# Altium schematic sheet ESP32_VCC.SchDoc
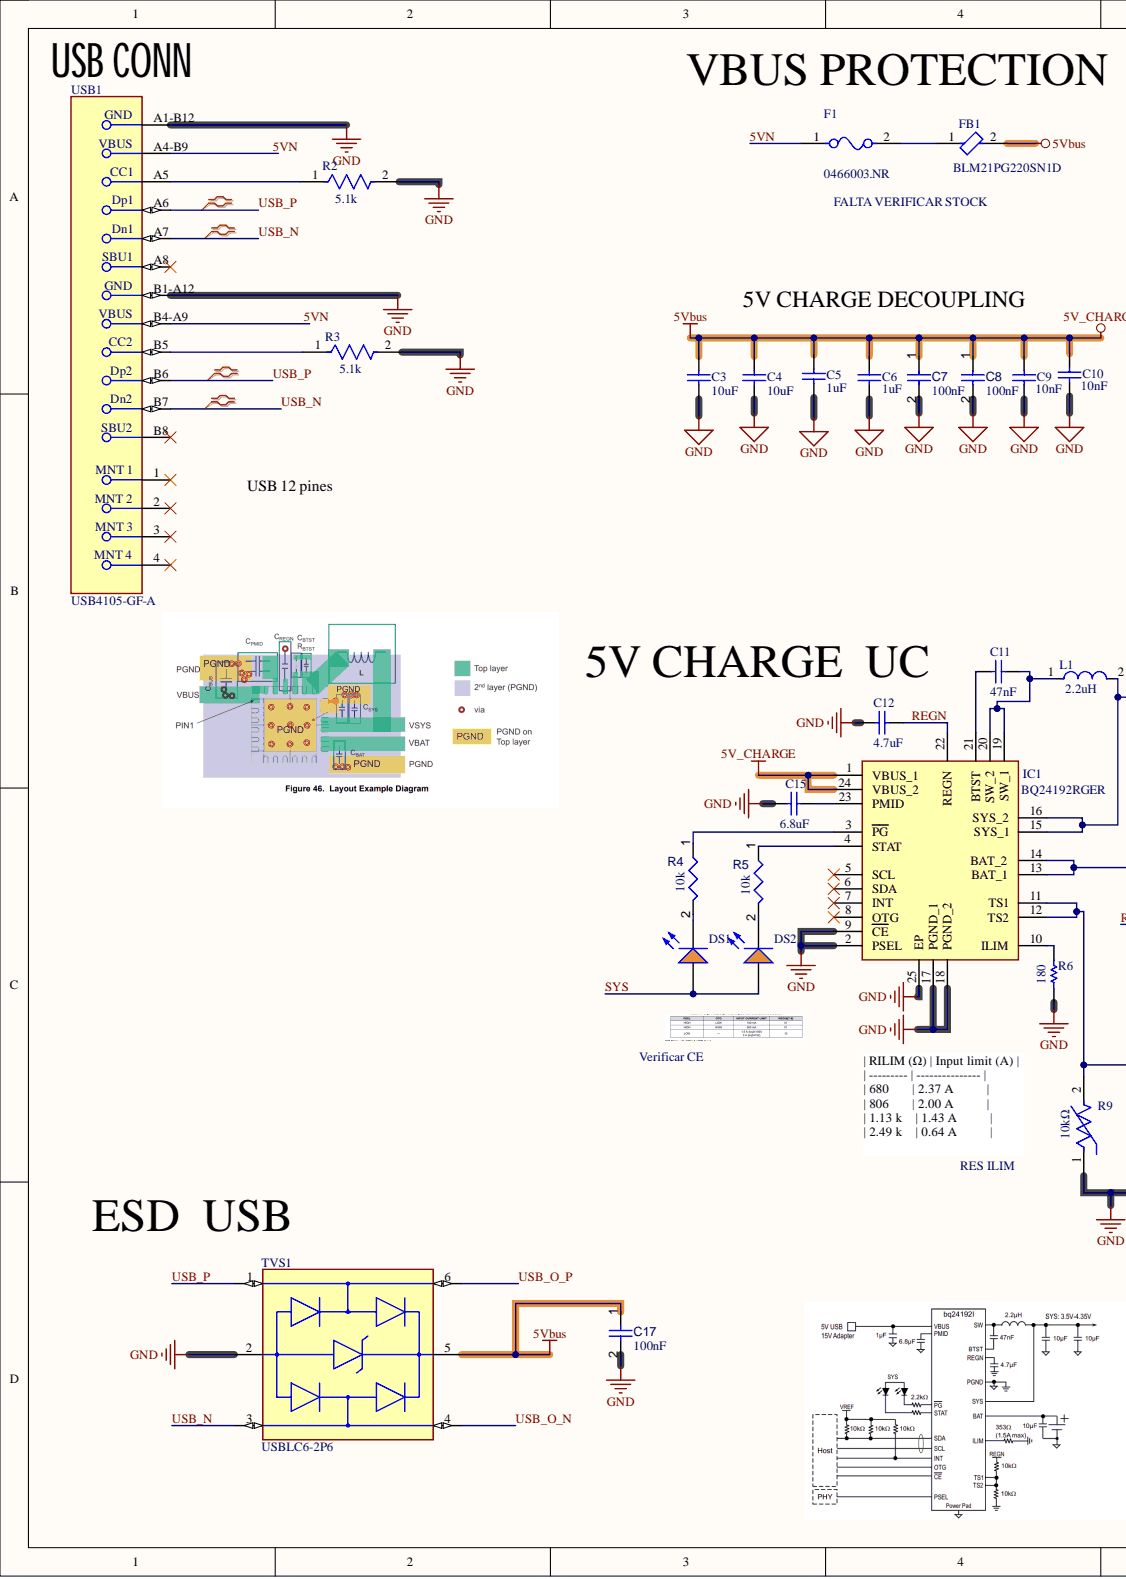
[diagram p0: ESP32_VCC.SchDoc, left]
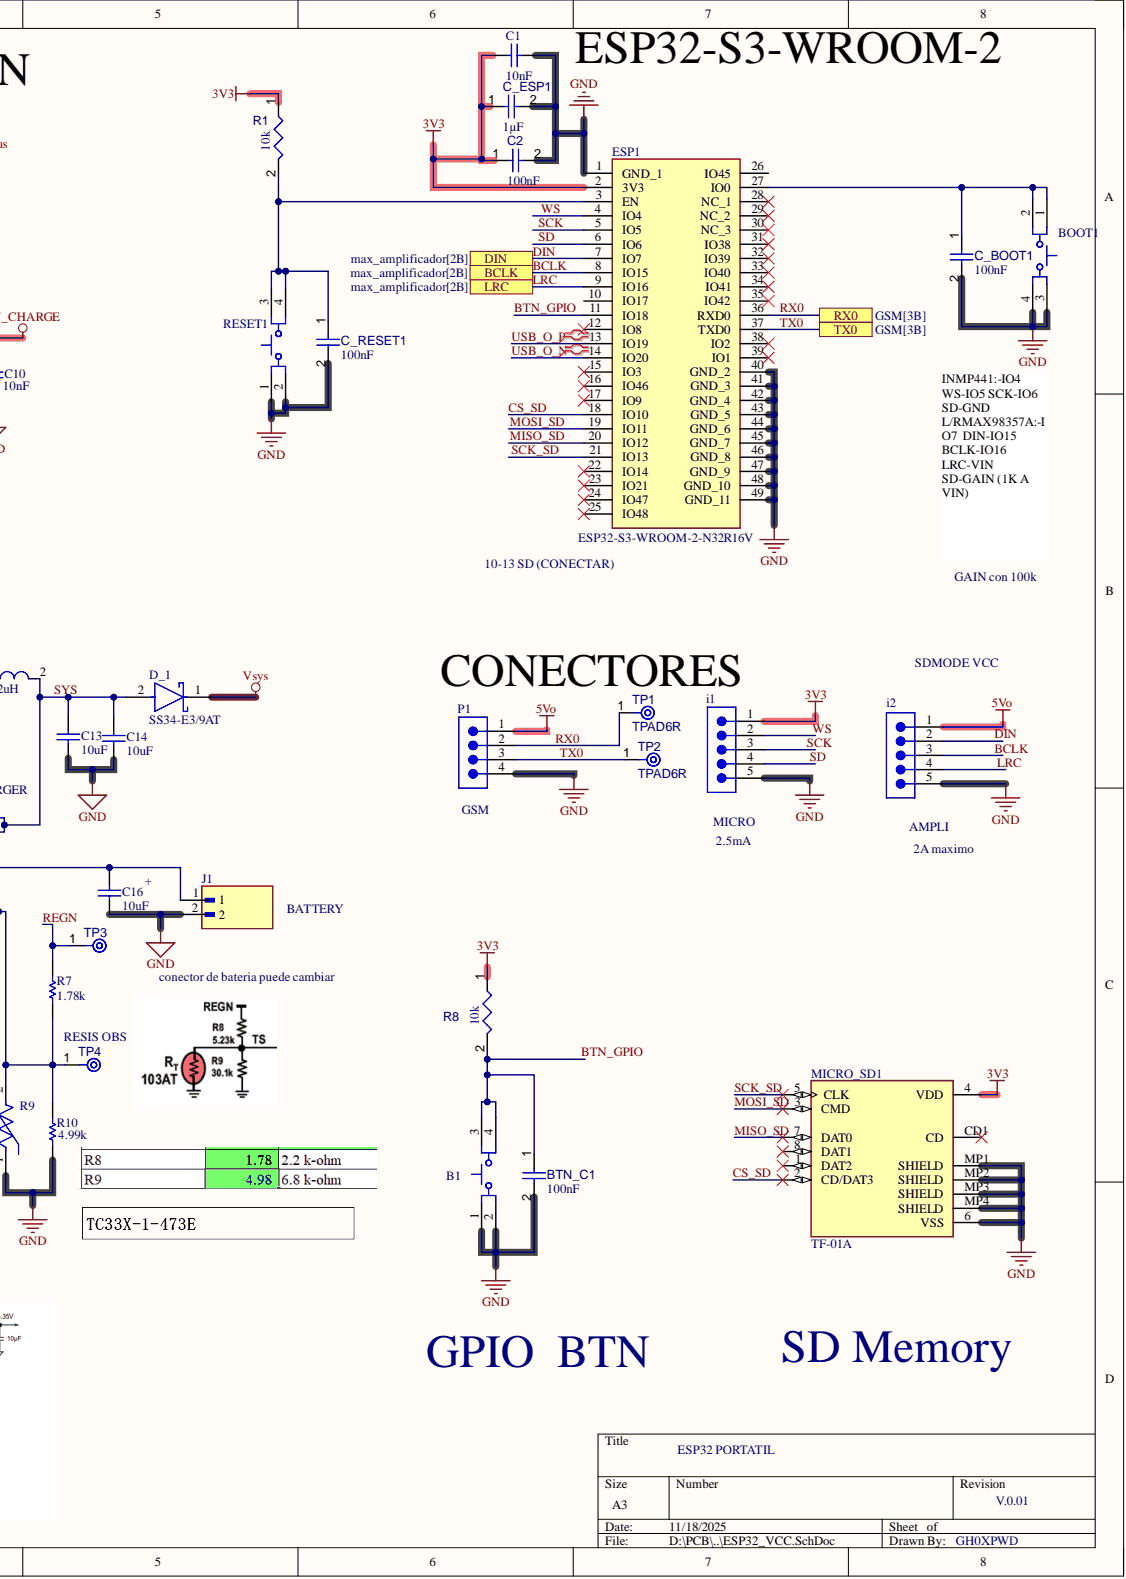
[diagram p1: ESP32_VCC.SchDoc, right]

## Parts
B1  TL3342F160QG  [ESWI-TL3342F160QG_V]  (value not drawn)
BOOT1  TL3342F160QG  [ESWI-TL3342F160QG_V]  (value not drawn)
BTN_C1  value 100nF  [CAPC1005X56X25ML10T15]  (hidden comment: Capacitor 0.1 uF +/- 5% 16 V 0402)
C1  value 10nF  [CAPC0603X33X15NL03T05]  (hidden comment: 0201ZC103JAT2A)
C2  value 100nF  [CAPC1005X56X25NL10T15]  (hidden comment: Capacitor 0.1 uF +/- 5% 16 V 0402)
C3  value 10uF  [CAPC0603(1608)100_N]  (hidden comment: CAP 10uF 6.3V 0603(1608))
C4  value 10uF  [CAPC0603(1608)100_N]  (hidden comment: CAP 10uF 6.3V 0603(1608))
C5  value 1uF  [CAPC1608X90X35NL15T15]  (hidden comment: 0603YD105KAT4A)
C6  value 1uF  [CAPC1608X90X35NL15T15]  (hidden comment: 0603YD105KAT4A)
C7  value 100nF  [CAPC1005X56X25ML10T15]  (hidden comment: Capacitor 0.1 uF +/- 5% 16 V 0402)
C8  value 100nF  [CAPC1005X56X25ML10T15]  (hidden comment: Capacitor 0.1 uF +/- 5% 16 V 0402)
C9  value 10nF  [CAPC0603X33X15NL03T05]  (hidden comment: 0201ZC103JAT2A)
C10  value 10nF  [CAPC0603X33X15NL03T05]  (hidden comment: 0201ZC103JAT2A)
C11  value 47nF  [CAPC1608X92X38NL15T08]  (hidden comment: VJ0603Y473KXAAC)
C12  value 4.7uF  [CAPC0603(1608)100_N]  (hidden comment: CAP 4.7uF 10V 0603(1608))
C13  value 10uF  [CAPC0603(1608)100_L]  (hidden comment: CAP 10uF 6.3V 0603(1608))
C14  value 10uF  [CAPC0603(1608)100_L]  (hidden comment: CAP 10uF 6.3V 0603(1608))
C15  value 6.8uF  [CAPC1609X100X15NL20]  (hidden comment: TACL685K010XTA)
C16  value 10uF  [CAPC0603(1608)100_N]  (hidden comment: CAP 10uF 6.3V 0603(1608))
C17  value 100nF  [CAPC1005X56X25ML10T15]  (hidden comment: Capacitor 0.1 uF +/- 5% 16 V 0402)
C_BOOT1  value 100nF  [CAPC1005X56X25ML10T15]  (hidden comment: Capacitor 0.1 uF +/- 5% 16 V 0402)
C_ESP1  value 1µF  [CAPC1608X94X35NL15T15]  (hidden comment: Capacitor 1 uF +/- 10% 16 V 0603)
C_RESET1  value 100nF  [CAPC1005X56X25ML10T15]  (hidden comment: Capacitor 0.1 uF +/- 5% 16 V 0402)
D_1  SS34-E3/9AT  [FP-SMC-MFG]
DS1  LTST-C190KFKT  [LTST-C190KFKT_V]  (value not drawn)
DS2  LTST-C190KFKT  [LTST-C190KFKT_V]  (value not drawn)
ESP1  ESP32-S3-WROOM-2-N32R16V  [ESP32S3WROOM2N32R16V]
F1  0466003.NR  [FP-0466-MFG]
FB1  BLM21PG220SN1D  [FP-BLM21-0_2-t0_85-e0_5-IPC_A]
i1  PHT-105-02-L-S  [SMTC-PHT-105-02-X-S]  (value not drawn)
i2  PHT-105-02-L-S  [SMTC-PHT-105-02-X-S]  (value not drawn)
IC1  BQ24192RGER  [QFN50P400X400X100-25N-D]
J1  S2B-XH-A(LF)(SN)  [JST_S2B-XH-A(LF)(SN)]  (value not drawn)
L1  Inductance: 2.2uH  [FP-MPX1D1250-MFG]  (hidden comment: MPX1D1250L2R2)
MICRO_SD1  TF-01A  [TF-01A]
P1  PHT-104-01-L-S  [SMTC-PHT-104-01-X-S]  (value not drawn)
R1  value 10k  [RESC1608X55X25LL10T15]  (hidden comment: Resistor 10k +/-1% 0603 100 mW)
R2  Resistance: 5.1k  [FP-RMCF0603-IPC_A]  (hidden comment: RMCF0603JG5K10)
R3  Resistance: 5.1k  [FP-RMCF0603-IPC_A]  (hidden comment: RMCF0603JG5K10)
R4  value 10k  [RESC1608X55X25LL10T15]  (hidden comment: Resistor 10k +/-1% 0603 100 mW)
R5  value 10k  [RESC1608X55X25LL10T15]  (hidden comment: Resistor 10k +/-1% 0603 100 mW)
R6  value 180  [RESC1608X55X25ML10T15]  (hidden comment: RC0603FR-07180RL)
R7  value 1.78k  [RESC1005X40X25LL05T05]  (hidden comment: CRCW0402178KFKED)
R8  value 10k  [RESC1608X55X25LL10T15]  (hidden comment: Resistor 10k +/-1% 0603 100 mW)
R9  Resistance: 10kΩ  [FP-NTCLE100E3103JT2-MFG]  (hidden comment: NTC)
R10  value 4.99k  [RESC1005X40X25LL05T10]  (hidden comment: RC0402FR-07499KL)
RESET1  TL3342F160QG  [ESWI-TL3342F160QG_V]  (value not drawn)
TP1  TPAD6R  [TP06R]  (no BOM)
TP2  TPAD6R  [TP06R]  (no BOM)
TP3  TPAD6R  [TP06R]  (value not drawn)  (no BOM)
TP4  TPAD6R  [TP06R]  (value not drawn)  (no BOM)
TVS1  USBLC6-2P6  [FP-SOT-666-MFG]
USB1  USB4105-GF-A  [FP-USB4105-GF-A-MFG]

## Ports
BCLK, DIN, LRC, RX0, TX0

## Nets
3V3: C1.2 C2.1 C_ESP1.1 ESP1.2(3V3) i1.1 MICRO_SD1.4(VDD) R1.1 R8.1
5V_CHARGE: C3.2 C4.2 C5.1 C6.1 C7.1 C8.1 C9.2 C10.2 C17.1 FB1.2 IC1.1(VBUS_1) IC1.24(VBUS_2) TVS1.5
5Vo: i2.1 P1.1
GND: B1.1 B1.2 BOOT1.3 BOOT1.4 BTN_C1.2 C1.1 C2.2 C3.1 C4.1 C5.2 C6.2 C7.2 C8.2 C9.1 C10.1 C12.2 C13.1 C14.1 C15.1 C16.1 C17.2 C_BOOT1.2 C_ESP1.2 C_RESET1.2 ESP1.1(GND_1) ESP1.40(GND_2) ESP1.41(GND_3) ESP1.42(GND_4) ESP1.43(GND_5) ESP1.44(GND_6) ESP1.45(GND_7) ESP1.46(GND_8) ESP1.47(GND_9) ESP1.48(GND_10) ESP1.49(GND_11) i1.5 i2.5 IC1.2(PSEL) IC1.9(CE) IC1.17(PGND_1) IC1.18(PGND_2) IC1.25(EP) J1.2 MICRO_SD1.6(VSS) MICRO_SD1.MP1(SHIELD) MICRO_SD1.MP2(SHIELD) MICRO_SD1.MP3(SHIELD) MICRO_SD1.MP4(SHIELD) P1.4 R2.2 R3.2 R6.2 R9.1 R10.1 RESET1.1 RESET1.2 TVS1.2 USB1.A1-B12 USB1.B1-A12
Vsys: D_1.1
5VN: F1.1 USB1.A4-B9 USB1.B4-A9
BCLK: ESP1.8(IO15) i2.3 ; port BCLK
BTN_GPIO: B1.3 B1.4 BTN_C1.1 ESP1.11(IO18) R8.2
CS_SD: ESP1.18(IO10) MICRO_SD1.2(CD/DAT3)
DIN: ESP1.7(IO7) i2.2 ; port DIN
LRC: ESP1.9(IO16) i2.4 ; port LRC
MISO_SD: ESP1.20(IO12) MICRO_SD1.7(DAT0)
MOSI_SD: ESP1.19(IO11) MICRO_SD1.3(CMD)
REGN: C12.1 IC1.22(REGN) R7.2 TP3.1
RX0: ESP1.36(RXD0) P1.2 TP1.1 ; port RX0
SCK: ESP1.5(IO5) i1.3
SCK_SD: ESP1.21(IO13) MICRO_SD1.5(CLK)
SD: ESP1.6(IO6) i1.4
SYS: C13.2 C14.2 D_1.2 DS1.1 DS2.1 IC1.15(SYS_1) IC1.16(SYS_2) L1.2
TX0: ESP1.37(TXD0) P1.3 TP2.1 ; port TX0
USB_N: TVS1.3 USB1.A7 USB1.B7
USB_O_N: ESP1.14(IO20) TVS1.4
USB_O_P: ESP1.13(IO19) TVS1.6
USB_P: TVS1.1 USB1.A6 USB1.B6
WS: ESP1.4(IO4) i1.2
NetBOOT1_1: BOOT1.1 BOOT1.2 C_BOOT1.1 ESP1.27(IO0)
NetC11_1: C11.1 IC1.21(BTST)
NetC11_2: C11.2 IC1.19(SW_1) IC1.20(SW_2) L1.1
NetC15_2: C15.2 IC1.23(PMID)
NetC16_2: C16.2 IC1.13(BAT_1) IC1.14(BAT_2) J1.1
NetC_RESET1_1: C_RESET1.1 ESP1.3(EN) R1.2 RESET1.3 RESET1.4
NetDS1_2: DS1.2 R4.2
NetDS2_2: DS2.2 R5.2
NetF1_2: F1.2 FB1.1
NetIC1_3: IC1.3(PG) R4.1
NetIC1_4: IC1.4(STAT) R5.1
NetIC1_10: IC1.10(ILIM) R6.1
NetIC1_11: IC1.11(TS1) IC1.12(TS2) R7.1 R9.2 R10.2 TP4.1
NetR2_1: R2.1 USB1.A5
NetR3_1: R3.1 USB1.B5

Not connected: ESP1 10(IO17) 12(IO8) 15(IO3) 16(IO46) 17(IO9) 22(IO14) 23(IO21) 24(IO47) 25(IO48) 26(IO45) 28(NC_1) 29(NC_2) 30(NC_3) 31(IO38) 32(IO39) 33(IO40) 34(IO41) 35(IO42) 38(IO2) 39(IO1); IC1 5(SCL) 6(SDA) 7(INT) 8(OTG); MICRO_SD1 1(DAT2) 8(DAT1) CD1(CD); USB1 1 2 3 4 A8 B8

## Library data of the parts
B1 BOOT1 RESET1 = CMP-011-00008-2: Tactile Switch, SPST-NO, 50 mA, 12 V, 4-Pin SMD, RoHS, Tape and Reel; Manufacturer: E-Switch; Current Rating: 50mA; Max Voltage Rating (DC): 12V; Operating Force: 1.6N; Throw Configuration: SPST; Case/Package: TL3342F160QG; Component Kind: Standard; Contact Material: Silver; Contact Plating: Silver; Datasheet URL: http://spec_sheets.e-switch.com/specs/P010632.pdf; Datasheet Version: Rev. I, 12/2010; Manufacturer URL: http://www.e-switch.ch/en/; Mounting Technology: SM; Package Description: 4-Pin Surface Mount Device, Body 5 x 5 mm, Pitch 6.3 mm; Package Quantity: 3000; Package Reference: TL3342F160QG; Packaging: TapeandReel; RoHS Compliant: True; Voltage Rating (DC): 12V; Library Name: Carlos Christopher Carbajal Jordán
BTN_C1 C2 C7 C8 C17 C_BOOT1 C_RESET1 = CMP-001-00001-6: Chip Capacitor, 0.1 uF, +/- 5%, 16 V, 0402 (1005 Metric); Capacitor Type: Ceramic; Voltage Rating: 16V; Case/Package: 0402; Component Kind: Standard; Max Operating Temperature: 125°C; Min Operating Temperature: -55°C; Mounting Technology: SM; Pins: 2; RoHS Compliant: True; Tolerance: 5%; Library Name: Carlos Christopher Carbajal Jordán
C1 C9 C10 = CMP-2010-00529-1: Manufacturer: AVX Interconnect / Elco; Component Kind: Standard; Library Name: Altium Content Vault; Part Number: 0201ZC103JAT2A
C3 C4 C13 C14 C16 = CMP-1035-02796-1: CAP 10uF 6.3V ±10% 0603 (1608 Metric) Thickness 1mm SMD; Case-EIA: 0603; Case-Metric: 1608; Tolerance: Â±10%; Component Kind: Standard; Library Name: Altium Content Vault; Max Thickness: 1 mm; Rated Voltage: 6.3 V; Technology: SMT
C5 C6 = CMP-2006-04533-1: Manufacturer: AVX Interconnect / Elco; Component Kind: Standard; Library Name: Altium Content Vault; Part Number: 0603YD105KAT4A
C11 = CMP-2006-01132-1: Manufacturer: Vitramon; Component Kind: Standard; Library Name: Altium Content Vault; Part Number: VJ0603Y473KXAAC
C12 = CMP-1035-02785-1: CAP 4.7uF 10V ±10% 0603 (1608 Metric) Thickness 1mm SMD; Case-EIA: 0603; Case-Metric: 1608; Tolerance: Â±10%; Component Kind: Standard; Library Name: Altium Content Vault; Max Thickness: 1 mm; Rated Voltage: 10 V; Technology: SMT
C15 = CMP-2006-00206-1: Manufacturer: AVX Interconnect / Elco; Component Kind: Standard; Library Name: Altium Content Vault; Part Number: TACL685K010XTA
C_ESP1 = CMP-001-00003-6: Chip Capacitor, 1 uF, +/- 10%, 16 V, 0603 (1608 Metric); Capacitor Type: Ceramic; Voltage Rating: 16V; Case/Package: 0603; Component Kind: Standard; Max Operating Temperature: 125°C; Min Operating Temperature: -55°C; Mounting Technology: SM; Pins: 2; RoHS Compliant: True; Tolerance: 10%; Library Name: Carlos Christopher Carbajal Jordán
D_1 = CMP-02539-000066-1: DIODE SCHOTTKY 40V 3A DO214AB; Element Configuration: Single; Forward Voltage: 500mV; Max Reverse Current: 500uA; Max Surge Current: 100A; Average Rectified Current: 3A; Case/Package: SMC; Component Kind: Standard; Forward Current: 3A; Library Name: Altium Content Vault; Max Operating Temperature: 125°C; Max Repetitive Reverse Voltage (Vrrm): 40V; Max Reverse Leakage Current: 500uA; Max Reverse Voltage (DC): 40V; Min Operating Temperature: -55°C; Mount: Surface Mount; Number of Pins: 2; Package Quantity: 3500; Packaging: Tape and Reel; Peak Non-Repetitive Surge Current: 100A; Radiation Hardening: No; RoHS Compliant: Yes; Series: -
DS1 DS2 = CMP-007-00023-2: LED Uni-Color Orange, 75 mW, 30 mA, -35 to 85 degC, 2-Pin SMD, RoHS, Tape and Reel; Manufacturer: Lite-On; Forward Current: 20mA; Forward Voltage: 2V; Lens Style: Diffused; Luminous Intensity: 90mcd; Power: 75mW; Viewing Angle: 130degrees; Case/Package: 0603; Colour: Orange; Component Kind: Standard; Datasheet URL: http://optoelectronics.liteon.com/upload/download/DS-22-99-0186/S_110_LTST-C190KFKT.pdf; Datasheet Version: Rev. I, 09/2011; Illumination Colour: Orange; Manufacturer URL: http://www.us.liteon.com/; Max Operating Temperature: 85°C; Min Operating Temperature: -55°C; Mounting Technology: SM; Nominal Vf: 2V; Package Description: 2-Pin Surface Mount Device, Body 1.6 x 0.8 mm; Package Quantity: 3000; Package Reference: C190KFKT; Packaging: TapeandReel; Pins: 2; RoHS Compliant: True; Library Name: Carlos Christopher Carbajal Jordán
ESP1 = ESP32-S3-WROOM-2-N32R16V: Integrated Circuit; Manufacturer_Name: Espressif Systems; Component Kind: Standard; Datasheet Link: https://www.digikey.in/en/products/detail/espressif-systems/ESP32-S3-WROOM-2-N32R16V/25811280; Height: 3.25mm; Library Name: BQ24193.SchLib; Manufacturer_Part_Number: ESP32-S3-WROOM-2-N32R16V; Mouser Part Number: 356-SP32S3WM2N32R16V; Mouser Price/Stock: https://www.mouser.co.uk/ProductDetail/Espressif-Systems/ESP32-S3-WROOM-2-N32R16V?qs=%252BHhoWzUJg4Kf%2FwmS40wg%252BQ%3D%3D
F1 = CMP-08605-000054-1: FUSE BOARD MNT 3A 32VAC/VDC 1206; Manufacturer: Littelfuse; Fuse Blow Speed: Fast; Fuse I2T: 0.576A2Sec; Fuse Interrupt Ac Current: 50A; Fuse Voltage Drop: 75.99mV; Max Fuse Ac Voltage: 32V; Resistance: 0.019Ω; Value: 0.019Ω; Automotive: No; Category: Fuse; Component Kind: Standard; ComponentLink2Description: Datasheet; ComponentLink2URL: https://www.littelfuse.com/~/media/electronics/datasheets/fuses/littelfuse_fuse_466_datasheet.pdf.pdf; Device Class L1: Circuit Protection; Device Class L2: Fuses; Device Class L3: unset; Digikey Description: FUSE BOARD MNT 3A 32VAC/VDC 1206; Fuse Current: 3A; Fuse Interrupt Dc Current: 50A; Height: 0.66mm; Lead Free: Yes; Library Name: Altium Content Vault; Manufacturer Part Number: 0466003.NR; Max Fuse Dc Voltage: 32V; Mouser Description: Surface Mount Fuses 32V 3A VFA Slimline; Octopart: https://octopart.com/0466003.nr-littelfuse-565175; Package: 0466; RoHS: TRUE; Temperature: -55°C to +90°C; Temperature Range High: +90°C; Temperature Range Low: -55°C; Voltage Rating: 32V
FB1 = CMP-0686-00622-4: Chip Ferrite Bead, 0805, 22Ω @ 100MHz, 0.009Ω, 25%, 6A; Manufacturer Series: BLM21P; DC Resistance (DCR): 9mR; Impedance: 22R; Material: Steel; Number of Circuits: 1; Resistance: 10mR; Shielding: Unshielded; Case Code (Imperial): 0805; Case Code (Metric): 2012; Case/Package: 0805; Component Kind: Standard; Core Material: Ferrite; Current Rating: 6A; DC Current: 6A; DC Resistance-Max: 9mR; Depth: 1.25mm; Height: 0.85mm; Lead Free: Lead Free; Length: 2mm; Library Name: Altium Content Vault; Max Current Rating: 6A; Max DC Current: 6A; Max Operating Temperature: 125°C; Min Operating Temperature: -55°C; Number of Functions: 1; Number of Lines: 1; Number of Pins: 2; Package Quantity: 1; Packaging: Tape and Reel; Radiation Hardening: No; REACH SVHC: No SVHC; RoHS Compliant: Yes; Series: BLM21P; Terminal Finish: Tin; Termination: SMD/SMT; Test Frequency: 100MHz; Tolerance: 25%; Weight: 0.000194oz; Width: 1.25mm
i1 i2 = CMP-1024-00186-1: Press Fit Header, Through-hole, Vertical, -55 to 125 degC, 2.54 mm Pitch, 5-Pin, Male, RoHS; Manufacturer: Samtec; Pitch: 2.54 mm; Component Kind: Standard; ComponentLink1Description: Manufacturer URL; ComponentLink1URL: http://www.samtec.com; ComponentLink2Description: Datasheet; ComponentLink2URL: http://www.samtec.com/documents/webfiles/pdf/pht.pdf; ComponentLink3Description: Package Specification; ComponentLink3URL: http://www.samtec.com/documents/webfiles/cpdf/PHT-1XX-XX-X-X-XXX-MKT.pdf; DatasheetVersion: F-214; Library Name: Altium Content Vault; Mounting Technology: Through-hole; Orientation: Vertical; PackageDescription: .100 Press Fit Socket Assembly; PackageReference: PHT-105-02-X-S; PackageVersion: J, 9/2009; PartNumber: PHT-105-02-L-S; RoHS: True; Temperature Range: -55 to 125 degC
IC1 = BQ24192RGER: Integrated Circuit; Manufacturer_Name: Texas Instruments; Arrow Part Number: BQ24192RGER; Arrow Price/Stock: https://www.arrow.com/en/products/bq24192rger/texas-instruments; Component Kind: Standard; Datasheet Link: http://www.ti.com/lit/gpn/bq24192; Height: 1mm; Library Name: BQ24192RGER.SchLib; Manufacturer_Part_Number: BQ24192RGER; Mouser Part Number: 595-BQ24192RGER; Mouser Price/Stock: https://www.mouser.co.uk/ProductDetail/Texas-Instruments/BQ24192RGER?qs=2UFnnMkojnXNBLAi7bBu%2FA%3D%3D
J1 = CMP-002-00076-2: Connector Header Through Hole, Right Angle 2 position 0.098 (2.50mm); MF: JST Sales; Case/Package: None; Check_prices: https://www.snapeda.com/parts/S2B-XH-A(LF)(SN)/JST/view-part/?ref=eda; Component Kind: Standard; Component Type: Standard; Design Item ID: S2B-XH-A(LF)(SN); MP: S2B-XH-A(LF)(SN); Pins: 2; SnapEDA_Link: https://www.snapeda.com/parts/S2B-XH-A(LF)(SN)/JST/view-part/?ref=snap; Source: S2B-XH-A(LF)(SN).SchLib; Library Name: Carlos Christopher Carbajal Jordán
L1 = CMP-03027-000008-1: FIXED IND 2.2UH 21A 4.8 MOHM SMD; Core Material: Metal; DC Resistance (DCR): 4.8mR; Saturation Current: 28.5A; Self Resonant Frequency: 21MHz; Shielding: Shielded; Test Frequency: 100kHz; Tolerance: 20%; Case/Package: Nonstandard; Component Kind: Standard; Library Name: Altium Content Vault; Max Operating Temperature: 155°C; Min Operating Temperature: -55°C; Mount: Surface Mount; RoHS Compliant: Yes; Series: METCOM MPX
MICRO_SD1 = CMP-012-000000-3: Standard Card Edge Connectors; MF: HRO Electronics Co., Ltd.; Availability: Not in stock; Check_prices: https://www.snapeda.com/parts/TF-01A/HRO+Electronics+Co.%252C+Ltd./view-part/?ref=eda; Component Kind: Standard; Component Type: Standard; Design Item ID: TF-01A; MP: TF-01A; Package: Package; Pin Count: 13; Price: None; SnapEDA_Link: https://www.snapeda.com/parts/TF-01A/HRO+Electronics+Co.%252C+Ltd./view-part/?ref=snap; Source: TF-01A.SchLib; Library Name: Carlos Christopher Carbajal Jordán
P1 = CMP-1024-00182-1: Press Fit Header, Through-hole, Vertical, -55 to 125 degC, 2.54 mm Pitch, 4-Pin, Male, RoHS; Manufacturer: Samtec; Pitch: 2.54 mm; Component Kind: Standard; ComponentLink1Description: Manufacturer URL; ComponentLink1URL: http://www.samtec.com; ComponentLink2Description: Datasheet; ComponentLink2URL: http://www.samtec.com/documents/webfiles/pdf/pht.pdf; ComponentLink3Description: Package Specification; ComponentLink3URL: http://www.samtec.com/documents/webfiles/cpdf/PHT-1XX-XX-X-X-XXX-MKT.pdf; DatasheetVersion: F-214; Library Name: Altium Content Vault; Mounting Technology: Through-hole; Orientation: Vertical; PackageDescription: .100 Press Fit Socket Assembly; PackageReference: PHT-104-01-X-S; PackageVersion: J, 9/2009; PartNumber: PHT-104-01-L-S; RoHS: True; Temperature Range: -55 to 125 degC
R1 R4 R5 R8 = CMP-009-00017-5: Chip Resistor, 10 KOhm, +/- 1%, 01 W, -55 to 155 degC, 0603 (1608 Metric); Power: 100mW; Voltage Rating: 50V; Case/Package: 0603; Component Kind: Standard; Max Operating Temperature: 155°C; Min Operating Temperature: -55°C; Mounting Technology: SM; Pins: 2; RoHS Compliant: True; Tolerance: 1%; Library Name: Carlos Christopher Carbajal Jordán
R2 R3 = CMP-26527-001717-1: 5.1kΩ ±5% 0.1W 0603 Thick Film Chip Resistor AEC-Q200 compliant; Max Power Dissipation: 100mW; Temperature Coefficient: 200ppm/°C; Case Code (Imperial): 0603; Case Code (Metric): 1608; Case/Package: 0603; Component Kind: Standard; Contact Plating: Tin; Features: Automotive AEC-Q200; Height: 0.022inch; Length: 0.061inch; Library Name: Altium Content Vault; Max Operating Temperature: 155°C; Min Operating Temperature: -55°C; Mount: Surface Mount; Number of Terminations: 2; Package Quantity: 1; Packaging: Tape and Reel; Radiation Hardening: No; Resistor Type: Thick Film; RoHS Compliant: Yes; Series: RMCF; Tolerance: 5%; Width: 0.031inch
R6 = CMP-2000-06929-1: Chip Resistor, 180 Ohm, +/- 1%, 0.1 W, -55 to 155 degC, 0603 (1608 Metric), RoHS, Tape and Reel; Manufacturer: Yageo; Power Rating: 100mW; Resistance: 180Ohms; Temperature Coefficient: 100PPM/C; Voltage Rating: 50V; Case Code - in: 0603; Case Code - mm: 1608; Component Kind: Standard; Datasheet URL: http://www.yageo.com/documents/recent/PYu-RC_Group_51_RoHS_L_7.pdf; Datasheet Version: Rev. 7, 05/2017; Library Name: Altium Content Vault; Manufacturer URL: http://www.yageo.com/; Mounting Technology: SurfaceMount; Operating Temperature Range: -55Cto+155C; Package Description: 2-Pin Surface Mount Device, Body 1.6 x 0.8 mm; Package Reference: 0603; Packaging: Reel; Product: ThickFilmResistorsGeneralPurpose; Series: RC; Termination Style: SMD/SMT; Tolerance: 1%
R7 = CMP-1011-00762-2: Manufacturer: Vishay Dale; Component Kind: Standard; Library Name: Altium Content Vault; Part Number: CRCW0402178KFKED
R9 = CMP-00030-44472533-1: NTCLE100E3103JT2 Thermistor NTC 10K Ohm 5% 2-Pin Radial 3977K -4.5 to -4 T/R; Accuracy: 0.75%; Lead Diameter: 600µm; Max Power Dissipation: 500mW; Case/Package: Radial; Component Kind: Standard; Contact Plating: Tin; Depth: 3mm; Lead Pitch: 2.54mm; Length: 3.3mm; Library Name: Altium Content Vault; Max Operating Temperature: 125°C; Min Operating Temperature: -40°C; Mount: Through Hole; NexarPartId: 44472533; Number of Pins: 2; Packaging: Cut Tape (CT); Power Rating: 500mW; Radiation Hardening: No; REACH SVHC: Unknown; RoHS: Compliant; Schedule B: 8533400080, 8533400080; Termination: Radial; Tolerance: 5%
R10 = CMP-2002-07707-1: Manufacturer: Yageo / Phycomp; Component Kind: Standard; Library Name: Altium Content Vault; Part Number: RC0402FR-07499KL
TP1-TP4 = CMP-00028-00002-2: 0.6mm round; Pins: 1; Library Name: Carlos Christopher Carbajal Jordán
TVS1 = CMP-12225-000058-1: TVS DIODE 5.25V 17V SOT666; Breakdown Voltage: 6V; Capacitance: 3.5pF; Direction: Unidirectional; Element Configuration: Dual; ESD Protection: Yes; Leakage Current: 150nA; Number of Bidirectional Channels: 2; Number of Elements: 1; Operating Supply Voltage: 5V; Peak Pulse Current: 5A; Test Current: 1mA; Case/Package: SOT-666; Clamping Voltage: 17V; Component Kind: Standard; Contact Plating: Tin; Depth: 1.3mm; Height: 0.6mm; Lead Free: Lead Free; Length: 1.7mm; Library Name: Altium Content Vault; Max Breakdown Voltage: 6V; Max Operating Temperature: 125°C; Max Reverse Leakage Current: 150nA; Min Breakdown Voltage: 6V; Min Operating Temperature: -40°C; Mount: Surface Mount; Number of Channels: 2; Number of Pins: 6; Package Quantity: 1; Packaging: Tape and Reel; Polarity: Unidirectional; Power Line Protection: Yes; Radiation Hardening: No; REACH SVHC: No SVHC; Reverse Breakdown Voltage: 6V; Reverse Standoff Voltage: 5V; Termination: SMD/SMT; Width: 1.3mm; Working Voltage: 5V
USB1 = CMP-002-00071-1: USB Connector, 24 Contact(s), Female, Right Angle, Solder Terminal, Locking, Receptacle; Voltage Rating: 20V; Component Kind: Standard; Gender: Receptacle; Max Operating Temperature: 85°C; Min Operating Temperature: -40°C; Pins: 24; Library Name: Carlos Christopher Carbajal Jordán
